# Altium schematic sheet Sheet4.SchDoc
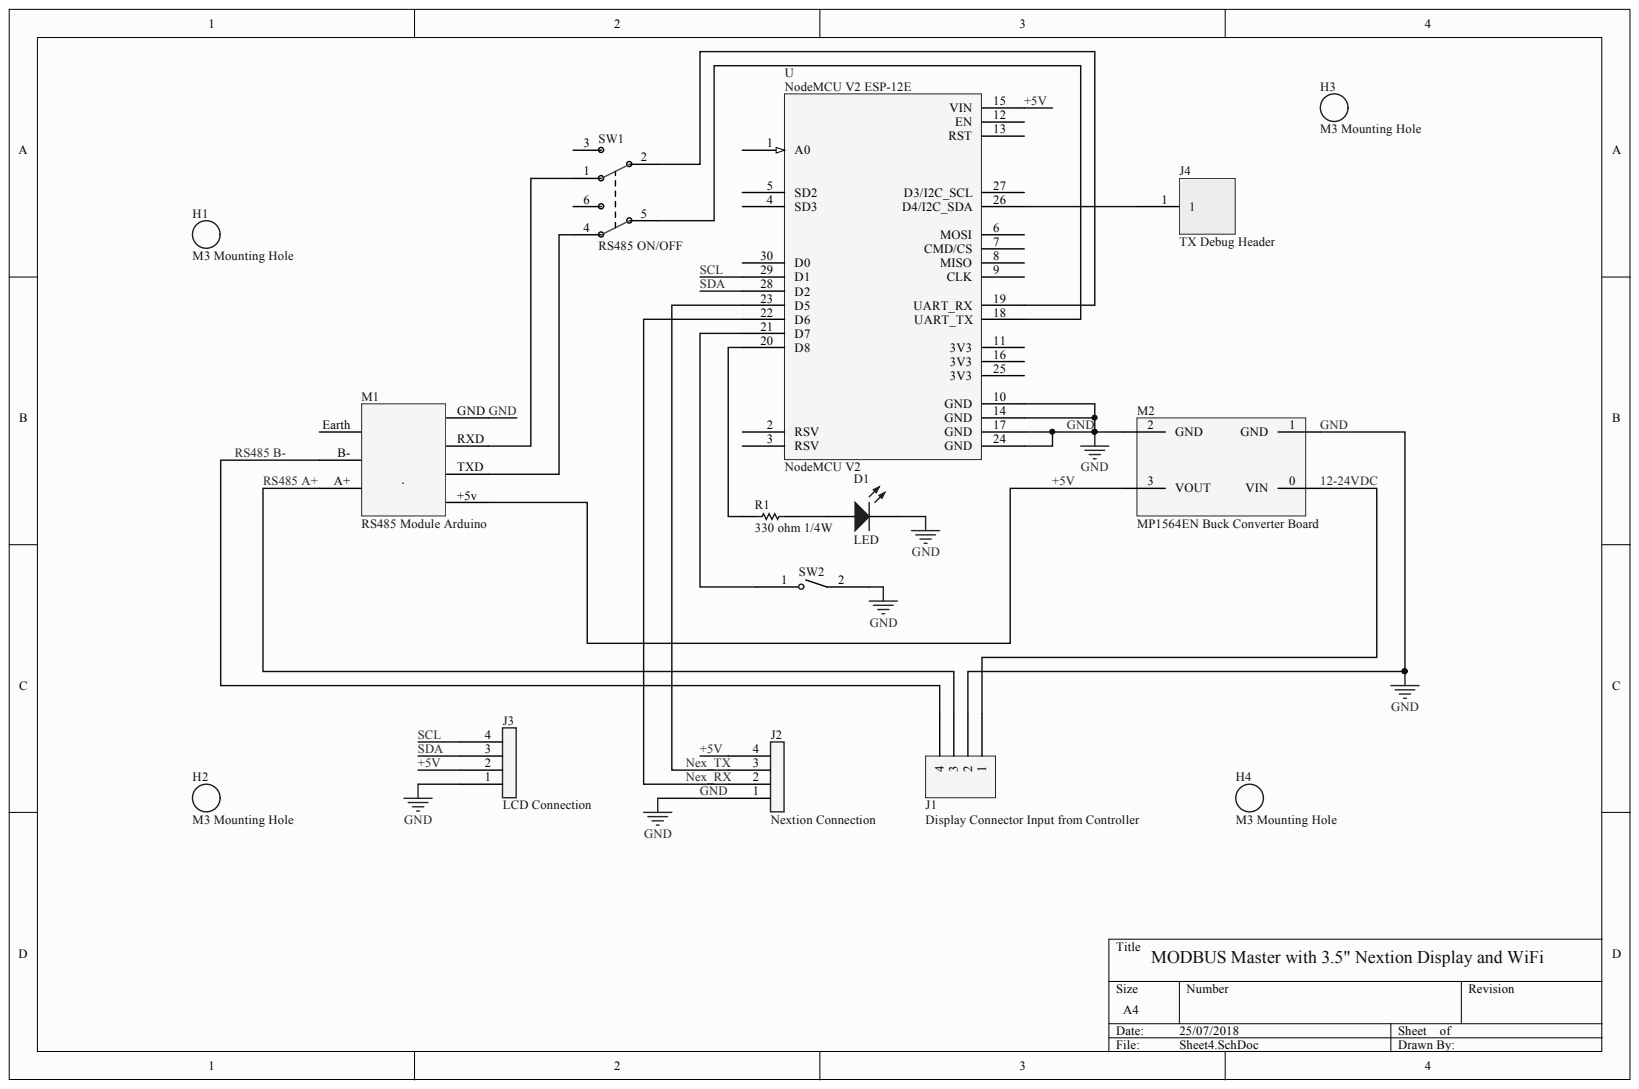
[diagram p0: Sheet4.SchDoc]

## Parts
D1  LED  [PCB-6hmn14p3euwrtpae4j9f-1]
H1  M3 Mounting Hole  [PCB-sb9r54v1ukudlsmquqqd-1]
H2  M3 Mounting Hole  [PCB-sb9r54v1ukudlsmquqqd-1]
H3  M3 Mounting Hole  [PCB-sb9r54v1ukudlsmquqqd-1]
H4  M3 Mounting Hole  [PCB-sb9r54v1ukudlsmquqqd-1]
J1  Display Connector Input from Controller  [PCB-7alpfj4z7cactpb4urhw-1]
J2  Nextion Connection  [PCB-tykp9fg8zejphh3ras5e-1]
J3  LCD Connection  [PCB-tykp9fg8zejphh3ras5e-1]
J4  TX Debug Header  [PCB-jazjlqhe2dz3b1rp09od-1]
M1  RS485 Module Arduino  [PCB-fd05ettsbss648nlesx9-1]
M2  MP1564EN Buck Converter Board  [PCB-li055xbo9p6zs65dyiu8-1]
R1  330 ohm 1/4W  [PCB-b0svi7yxgb64se8nuirk-1]
SW1  RS485 ON/OFF  [PCB-4o0hqz6pdnge3ng8ta2d-1]
SW2  TE Connectivity 1825910-7  [PCB-6xsl2ocvzxedp18o12ji-1]  (value not drawn)
U  NodeMCU V2  [PCB-1qpvucnc8d0r5y38cwmb-1]

## Nets
12-24VDC: J1.1 M2.0(VIN)
+5V: J2.4 J3.2 M1.+5v M2.3(VOUT) U.15(VIN)
GND: D1.2 J1.2 J2.1 J3.1 M1.GND M2.1(GND) M2.2(GND) SW2.2 U.10(GND) U.14(GND) U.17(GND) U.24(GND)
NEX_RX: J2.2 U.22(D6)
NEX_TX: J2.3 U.23(D5)
RS485 A+: J1.3 M1.A+
RS485 B-: J1.4 M1.B-
SCL: J3.4 U.29(D1)
SDA: J3.3 U.28(D2)
NetD1_1: D1.1 R1.1
NetJ4_1: J4.1 U.26(D4/I2C_SDA)
NetM1_Earth: M1.Earth
NetM1_RXD: M1.RXD SW1.1
NetM1_TXD: M1.TXD SW1.4
NetR1_2: R1.2 U.20(D8)
NetSW1_2: SW1.2 U.19(UART_RX)
NetSW1_3: SW1.3
NetSW1_5: SW1.5 U.18(UART_TX)
NetSW1_6: SW1.6
NetSW2_1: SW2.1 U.21(D7)

Not connected: U 1(A0) 2(RSV) 3(RSV) 4(SD3) 5(SD2) 6(MOSI) 7(CMD/CS) 8(MISO) 9(CLK) 11(3V3) 12(EN) 13(RST) 16(3V3) 25(3V3) 27(D3/I2C_SCL) 30(D0)

## Library data of the parts
D1 = CMP-9d1f5758637d42d8-1: TLHR5205 Red LED, 625 nm 5mm (T-1 3/4), Round Lens Through Hole package; Forward Voltage: 2.00 V; Lead-Free Status: Lead Free; Lead Length: 24.0 mm; Luminous Intensity: 70.0 mcd; Peak Wavelength: 635 nm; Viewing Angle: 14 °; Wavelength: 625 nm; Color: Red; Component Kind: Standard; Library Name: CommunityVault; Manufacturer Part Number: TLHR5205; Mounting Style: Through Hole, Radial; Number of Pins: 2; OctopartID: 9d1f5758637d42d8; Octopart URL: http://octopart.com/tlhr5205-vishay-55433988; RoHS: Compliant; Voltage Rating (DC): 2.00 V
H1-H4 = CMP-2eajnev4pcr11g3c6yc7-1: M3 Mountig Hole; Component Kind: Standard; CustomComponentID: 2eajnev4pcr11g3c6yc7; Library Name: CommunityVault
J1 = CMP-8a8e41e0d7ca5549-1: Header Shrouded 4 Position 5.08 mm Pitch Euro Style Terminal Block; Manufacturer Part Number: 796636-4; ELV Compliant: Compliant; Gender: Male; Lead-Free Status: Lead Free; Number of Contacts: 4; Color: Green; Component Kind: Standard; Contact Material: Phosphor Bronze; Contact Plating: Tin; Flammability Rating: UL 94 V-0; Housing Color: Green; Housing Material: Nylon 6/6; Library Name: CommunityVault; Lifecycle Status: Active; Mounting Style: Through Hole, Vertical; OctopartID: 8a8e41e0d7ca5549; Octopart URL: http://octopart.com/796636-4-te+connectivity-40138786; Orientation: Straight; Packaging: Box; REACH SVHC Compliance: No SVHC; RoHS: Compliant
J2 J3 = CMP-pb4m6phizd15df6m9aeg-2: 4 pin right angle header; Component Kind: Standard; CustomComponentID: pb4m6phizd15df6m9aeg; Library Name: CommunityVault
J4 = CMP-b1d07a80737d6e17-5: AMPMODU 1 Position 2.54 mm Single Row Through Hole Straight Header; Component Kind: Standard; Library Name: CommunityVault
M1 = CMP-3b2urf91aox2uunr70m7-5: RS485 Module with auto flow control; Component Kind: Standard; CustomComponentID: 3b2urf91aox2uunr70m7; Library Name: CommunityVault
M2 = CMP-21c200n1kdmmc9b8929b-12: Popular low profile breakout board; Component Kind: Standard; CustomComponentID: 21c200n1kdmmc9b8929b; Library Name: CommunityVault
R1 = CMP-b3d47a8ce28e6c13-3: Resistor; Metal Film; Res 1 Kilohms; Pwr-Rtg 0.25 W; Tol 0.1%; Axial; TCR 15 ppm/DegC; Manufacturer Part Number: YR1B1K0CC; ELV Compliant: Compliant; Lead Length: 25.0 mm; Temperature Coefficient: -15.0 ppm/°C to 15.0 ppm/°C; Voltage Rating (DC): 500 V; Component Kind: Standard; Library Name: CommunityVault; Lifecycle Status: Active; Mounting Style: Axial; Number of Pins: 2; OctopartID: b3d47a8ce28e6c13; Octopart URL: https://octopart.com/yr1b1k0cc-te+connectivity-5403708; Operating Temperature: -65.0 °C to 155 °C; Packaging: Ammo Pack; REACH SVHC Compliance: No SVHC; Resistance Tolerance: ±0.1%; RoHS: Compliant
SW1 = CMP-0b36c2500016d4f1-7: JS Series DPDT On-On PC Pin Through Hole Subminiature Slide Switch; Lead-Free Status: Lead Free; Component Kind: Standard; Library Name: CommunityVault; Mounting Style: PC Board, Through Hole; OctopartID: 0b36c2500016d4f1; Octopart URL: https://octopart.com/js202011cqn-c%26k+components-7089734; Orientation: Vertical; Packaging: Bulk; REACH SVHC Compliance: No SVHC; RoHS: Compliant
SW2 = CMP-03bacadb7a6bdc7d-2: Switch Tactile OFF (ON) SPST Round Button PC Pins 0.05A 24VDC 100000Cycles 2.55N Thru-Hole Loose; ELV Compliant: Compliant; Lead-Free Status: Lead Free; Color: Black; Component Kind: Standard; Contact Material: Copper Alloy; Contact Plating: Silver; Library Name: CommunityVault; Lifecycle Status: Active; Manufacturer Part Number: 1825910-7; Mounting Style: Through Hole; OctopartID: 525e99d176c88d99; Octopart URL: https://octopart.com/1825910-7-te+connectivity-42271216; RoHS: Compliant
U = CMP-e3f12eb858a53c36-4: NodeMCU V2 Featuring ESP-12E; Component Kind: Standard; Library Name: CommunityVault
